# Altium schematic sheet PD_Controller.SchDoc(PD_Controller)
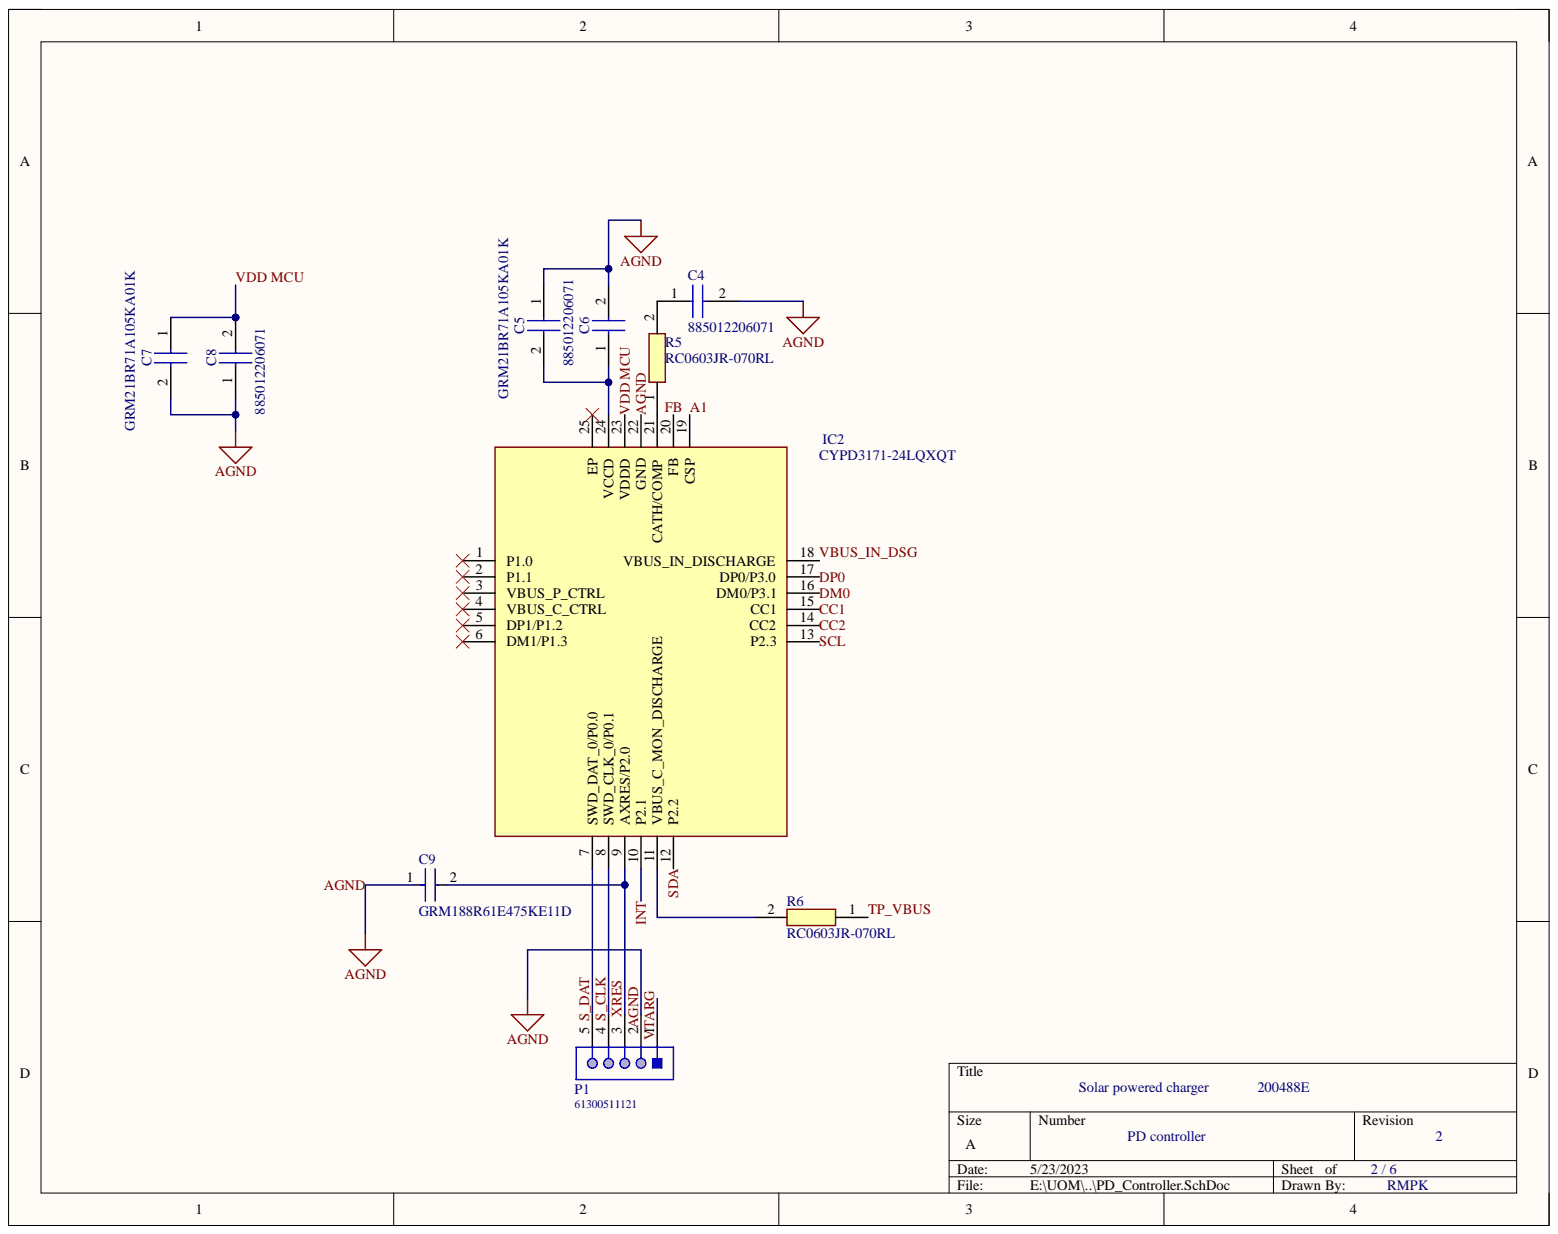
[diagram p0: PD_Controller.SchDoc]

## Parts
C4  885012206071  [CAPC1608X87N]
C5  GRM21BR71A105KA01K  [CAPC2012X145N]
C6  885012206071  [CAPC1608X87N]
C7  GRM21BR71A105KA01K  [CAPC2012X145N]
C8  885012206071  [CAPC1608X87N]
C9  GRM188R61E475KE11D  [FP-GRM188-0_15-IPC_C]
IC2  CYPD3171-24LQXQT  [QFN50P400X400X60-25N-D]
P1  61300511121  [61300511121]
R5  RC0603JR-070RL  [RESC1608X55N]
R6  RC0603JR-070RL  [RESC1608X55N]

## Nets
AGND: C4.2 C5.1 C6.2 C7.2 C8.1 C9.1 IC2.22(GND) P1.2
CC1: IC2.15(CC1)
CC2: IC2.14(CC2)
CSP: IC2.19(CSP)
DM0: IC2.16(DM0/P3.1)
DP0: IC2.17(DP0/P3.0)
FB: IC2.20(FB)
INT: IC2.10(P2.1)
S_CLK: IC2.8(SWD_CLK_0/P0.1) P1.4
S_DAT: IC2.7(SWD_DAT_0/P0.0) P1.5
SCL: IC2.13(P2.3)
SDA: IC2.12(P2.2)
VBUS_C_M_DSG: R6.1
VBUS_IN_DSG: IC2.18(VBUS_IN_DISCHARGE)
VDD MCU: C7.1 C8.2 IC2.23(VDDD)
VTARG: P1.1
XRES: C9.2 IC2.9(AXRES/P2.0) P1.3
NetC4_1: C4.1 R5.2
NetC5_2: C5.2 C6.1 IC2.24(VCCD)
NetIC2_11: IC2.11(VBUS_C_MON_DISCHARGE) R6.2
NetIC2_21: IC2.21(CATH/COMP) R5.1

Not connected: IC2 1(P1.0) 2(P1.1) 3(VBUS_P_CTRL) 4(VBUS_C_CTRL) 5(DP1/P1.2) 6(DM1/P1.3) 25(EP)

## Library data of the parts
C4 C6 C8 = 885012206071: Capacitor; Manufacturer_Name: Wurth Elektronik; Component Kind: Standard; Datasheet Link: https://katalog.we-online.com/pbs/datasheet/885012206071.pdf; Height: 0.87mm; Manufacturer_Part_Number: 885012206071; Mouser Part Number: 710-885012206071; Mouser Price/Stock: https://www.mouser.co.uk/ProductDetail/Wurth-Elektronik/885012206071?qs=0KOYDY2FL2%2FEBQkuHgvarg%3D%3D
C5 C7 = GRM21BR71A105KA01K: Capacitor; Manufacturer_Name: Murata Electronics; Arrow Part Number: GRM21BR71A105KA01K; Arrow Price/Stock: https://www.arrow.com/en/products/grm21br71a105ka01k/murata-manufacturing; Component Kind: Standard; Datasheet Link: http://www.murata.com/~/media/webrenewal/support/library/catalog/products/capacitor/mlcc/c02e.pdf; Height: 1.45mm; Manufacturer_Part_Number: GRM21BR71A105KA01K; Mouser Part Number: 81-GRM40X105K10K; Mouser Price/Stock: https://www.mouser.co.uk/ProductDetail/Murata-Electronics/GRM21BR71A105KA01K?qs=Rc6BINVvJ%2FiXBniUY2d%2FOg%3D%3D
C9 = CMP-2006-02523-2: Chip Multilayer Ceramic Capacitors for General Purpose, 0603, 4.7uF, X5R, 15%, 10%, 25V; Capacitance: 4.7uF; Case Code (Imperial): 0603; Case Code (Metric): 1608; Case/Package: 0603; Component Kind: Standard; Depth: 0.8mm; Dielectric: X5R; Height: 0.8mm; Lead Free: Lead Free; Length: 1.6mm; Library Name: Altium Content Vault; Material: Ceramic; Max Operating Temperature: 85°C; Min Operating Temperature: -55°C; Mount: Surface Mount; Package Quantity: 4000; Packaging: Cut Tape; REACH SVHC: No SVHC; Thickness: 0.031inch; Tolerance: 10%; Voltage: 25V; Voltage Rating: 25V; Voltage Rating (DC): 25V; Width: 0.031inch
IC2 = CYPD3171-24LQXQT: Integrated Circuit; Manufacturer_Name: Cypress Semiconductor; Arrow Part Number: CYPD3171-24LQXQT; Arrow Price/Stock: https://www.arrow.com/en/products/cypd3171-24lqxqt/cypress-semiconductor; Component Kind: Standard; Datasheet Link: https://www.infineon.com/dgdl/Infineon-EZ-PD(TM)_CCG3PA_Datasheet_USB_Type-C_Port_Controller-DataSheet-v09_00-EN.pdf?fileId=8ac78c8c7d0d8da4017d0ee438366ac0; Height: 0.6mm; Manufacturer_Part_Number: CYPD3171-24LQXQT; Mouser Part Number: 727-CYPD3171-24LQXQT; Mouser Price/Stock: https://www.mouser.co.uk/ProductDetail/Cypress-Semiconductor/CYPD3171-24LQXQT?qs=%252BEew9%252B0nqrAzf6gHzBBxqw%3D%3D
P1 = CMP-1502-01068-1: THT Vertical Pin Header WR-PHD, Pitch 2.54 mm, Single Row, 5 pins; Manufacturer: Wurth Elektronik; Contact Resistance: 20mOhm; Gender: Male; Pin Number: 5; Pitch: 2.54mm; Rated Current: 3A; Withstanding Voltage: 500VAC; Working Voltage: 250VAC; Component Kind: Standard; Datasheet URL: https://katalog.we-online.de/em/datasheet/6130xx11121.pdf; Library Name: Altium Content Vault; Manufacturer URL: http://www.we-online.de; Mounting Technology: THT; Operating Temperature: -40to105degC; Orientation: Vertical; Package Reference: 61300511121; Packaging: Bag; Part Number: 61300511121; Row Number: Single; Publisher: WurthElektronik
R5 R6 = RC0603JR-070RL: Resistor; Manufacturer_Name: YAGEO; Arrow Part Number: RC0603JR-070RL; Arrow Price/Stock: https://www.arrow.com/en/products/rc0603jr-070rl/yageo?region=europe; Component Kind: Standard; Datasheet Link: https://componentsearchengine.com/Datasheets/2/RC0603JR-070RL.pdf; Height: 0.55mm; Manufacturer_Part_Number: RC0603JR-070RL; Mouser Part Number: 603-RC0603JR-070RL; Mouser Price/Stock: https://www.mouser.co.uk/ProductDetail/YAGEO/RC0603JR-070RL?qs=2cAdsCoAWRGWyPcuXgGiXQ%3D%3D
